# Altium schematic sheet Audio.SchDoc
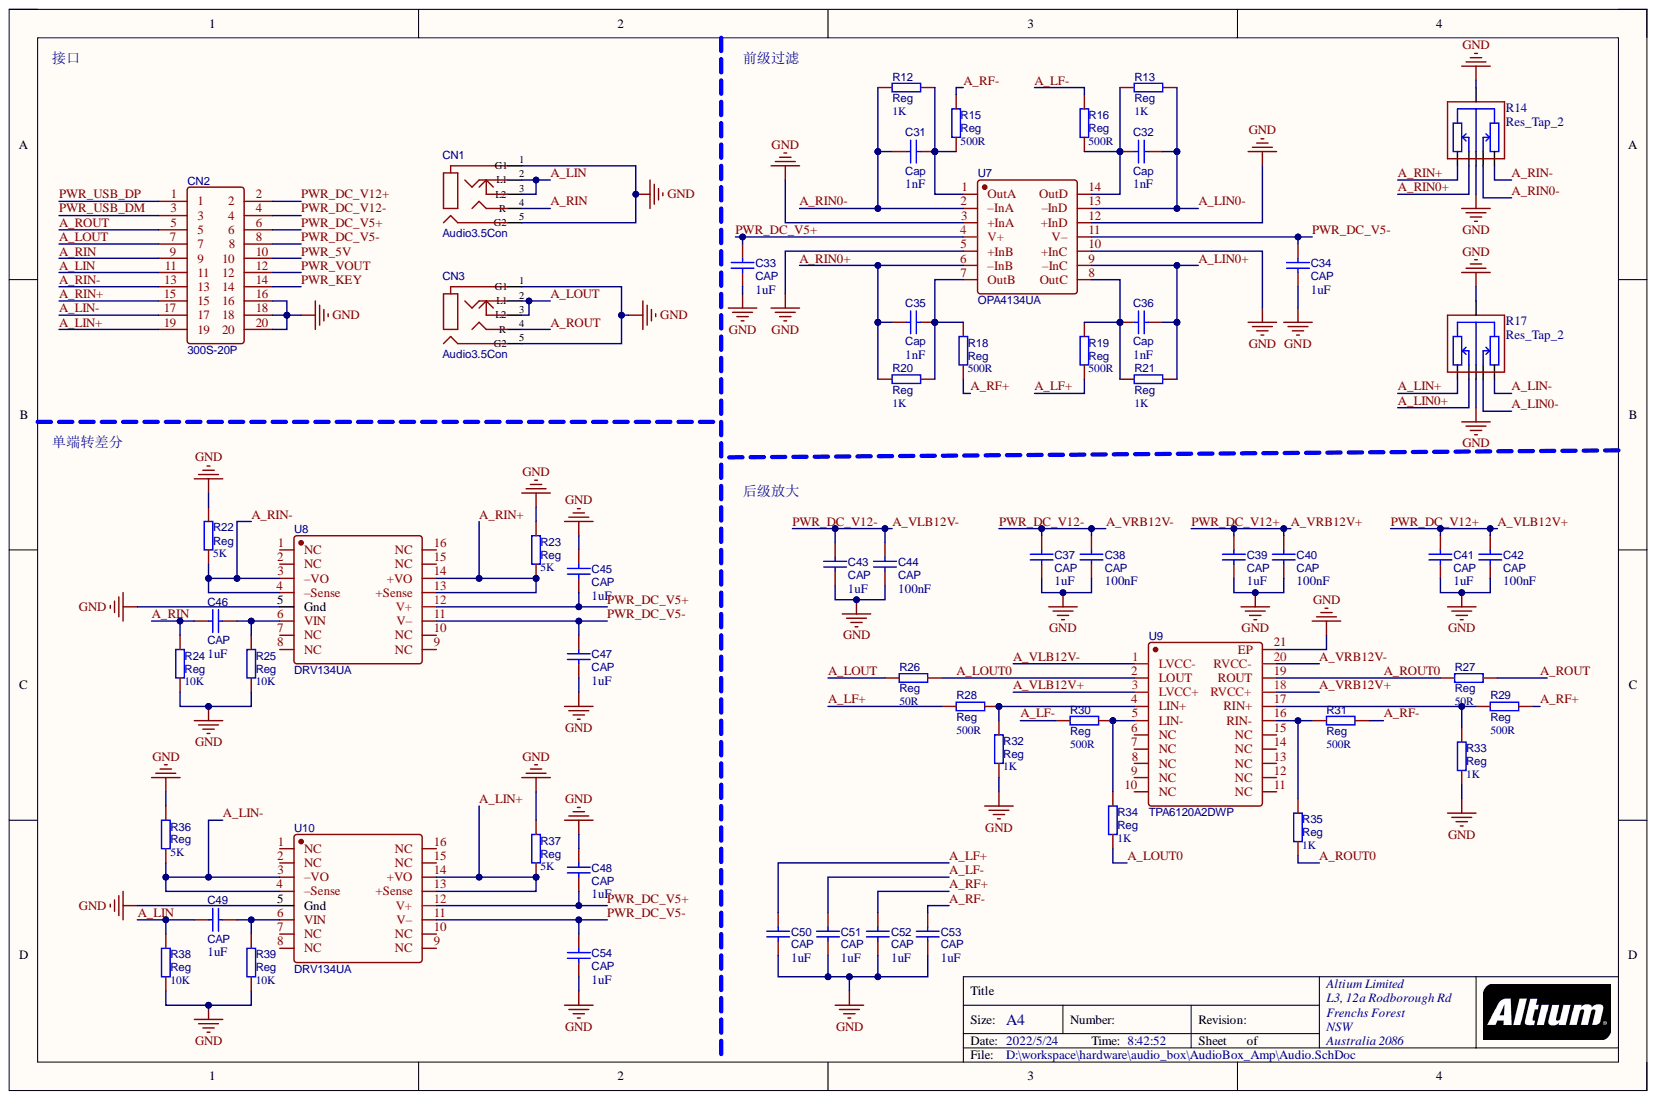
[diagram p0: Audio.SchDoc]

## Parts
C31  Cap  value 1nF  [C0603]
C32  Cap  value 1nF  [C0603]
C33  CAP  value 1uF  [C0603]
C34  CAP  value 1uF  [C0603]
C35  Cap  value 1nF  [C0603]
C36  Cap  value 1nF  [C0603]
C37  CAP  value 1uF  [C0603]
C38  CAP  value 100nF  [C0603]
C39  CAP  value 1uF  [C0603]
C40  CAP  value 100nF  [C0603]
C41  CAP  value 1uF  [C0603]
C42  CAP  value 100nF  [C0603]
C43  CAP  value 1uF  [C0603]
C44  CAP  value 100nF  [C0603]
C45  CAP  value 1uF  [C0603]
C46  CAP  value 1uF  [C0603]
C47  CAP  value 1uF  [C0603]
C48  CAP  value 1uF  [C0603]
C49  CAP  value 1uF  [C0603]
C50  CAP  value 1uF  [C0603]
C51  CAP  value 1uF  [C0603]
C52  CAP  value 1uF  [C0603]
C53  CAP  value 1uF  [C0603]
C54  CAP  value 1uF  [C0603]
CN1  Audio3.5Con  [Audio3.5_3Pin]
CN2  300S-20P  [CONN-TH_300S-20P]
CN3  Audio3.5Con  [Audio3.5_3Pin]
R12  Reg  value 1K  [R0603]
R13  Reg  value 1K  [R0603]
R14  Res_Tap_2  [RES_TAP_5Pin]
R15  Reg  value 500R  [R0603]
R16  Reg  value 500R  [R0603]
R17  Res_Tap_2  [RES_TAP_5Pin]
R18  Reg  value 500R  [R0603]
R19  Reg  value 500R  [R0603]
R20  Reg  value 1K  [R0603]
R21  Reg  value 1K  [R0603]
R22  Reg  value 5K  [R0603]
R23  Reg  value 5K  [R0603]
R24  Reg  value 10K  [R0603]
R25  Reg  value 10K  [R0603]
R26  Reg  value 50R  [R0603]
R27  Reg  value 50R  [R0603]
R28  Reg  value 500R  [R0603]
R29  Reg  value 500R  [R0603]
R30  Reg  value 500R  [R0603]
R31  Reg  value 500R  [R0603]
R32  Reg  value 1K  [R0603]
R33  Reg  value 1K  [R0603]
R34  Reg  value 1K  [R0603]
R35  Reg  value 1K  [R0603]
R36  Reg  value 5K  [R0603]
R37  Reg  value 5K  [R0603]
R38  Reg  value 10K  [R0603]
R39  Reg  value 10K  [R0603]
U7  OPA4134UA  [SOIC-14_L8.7-W3.9-P1.27-LS6.0-BL]
U8  DRV134UA  [SOIC-16_L10.3-W7.5-P1.27-LS10.3-BL]
U9  TPA6120A2DWP  [HSOP-20_L12.8-W7.5-P1.27-LS10.3-BL-EP]
U10  DRV134UA  [SOIC-16_L10.3-W7.5-P1.27-LS10.3-BL]

## Nets
GND: C33.1 C34.1 C37.1 C38.1 C39.1 C40.1 C41.1 C42.1 C43.1 C44.1 C45.2 C47.1 C48.2 C50.2 C51.2 C52.2 C53.2 C54.1 CN1.1 CN1.5(G2) CN2.16 CN2.18 CN2.20 CN3.1 CN3.5(G2) R14.1 R14.6 R17.1 R17.6 R22.2 R23.1 R24.1 R25.1 R32.1 R33.1 R36.2 R37.1 R38.1 R39.1 U7.3(+InA) U7.5(+InB) U7.10(+InC) U7.12(+InD) U8.5(Gnd) U9.21(EP) U10.5(Gnd)
A_LF+: C50.1 R19.1 R28.1
A_LF-: C51.1 R16.2 R30.1
A_LIN: C49.1 CN1.2(L1) CN1.3(L2) CN2.11 R38.2
A_LIN0+: C36.2 R17.3 R21.2 U7.9(–InC)
A_LIN0-: C32.2 R13.2 R17.2 U7.13(–InD)
A_LIN+: CN2.19 R17.4 R37.2 U10.13(+Sense) U10.14(+VO)
A_LIN-: CN2.17 R17.5 R36.1 U10.3(–VO) U10.4(–Sense)
A_LOUT: CN2.7 CN3.2(L1) CN3.3(L2) R26.1
A_LOUT0: R26.2 R34.1 U9.2(LOUT)
A_RF+: C52.1 R18.1 R29.1
A_RF-: C53.1 R15.2 R31.1
A_RIN: C46.1 CN1.4(R) CN2.9 R24.2
A_RIN0+: C35.1 R14.3 R20.1 U7.6(–InB)
A_RIN0-: C31.1 R12.1 R14.2 U7.2(–InA)
A_RIN+: CN2.15 R14.4 R23.2 U8.13(+Sense) U8.14(+VO)
A_RIN-: CN2.13 R14.5 R22.1 U8.3(–VO) U8.4(–Sense)
A_ROUT: CN2.5 CN3.4(R) R27.2
A_ROUT0: R27.1 R35.1 U9.19(ROUT)
A_VLB12V+: C39.2 C40.2 C41.2 C42.2 CN2.2 U9.3(LVCC+) U9.18(RVCC+)
A_VLB12V-: C37.2 C38.2 C43.2 C44.2 CN2.4 U9.1(LVCC-) U9.20(RVCC-)
PWR_5V: CN2.10
PWR_DC_V5+: C33.2 C45.1 C48.1 CN2.6 U7.4(V+) U8.12(V+) U10.12(V+)
PWR_DC_V5-: C34.2 C47.2 C54.2 CN2.8 U7.11(V–) U8.11(V–) U10.11(V–)
PWR_KEY: CN2.14
PWR_USB_DM: CN2.3
PWR_USB_DP: CN2.1
PWR_VOUT: CN2.12
NetC31_2: C31.2 R12.2 R15.1 U7.1(OutA)
NetC32_1: C32.1 R13.1 R16.1 U7.14(OutD)
NetC35_2: C35.2 R18.2 R20.2 U7.7(OutB)
NetC36_1: C36.1 R19.2 R21.1 U7.8(OutC)
NetC46_2: C46.2 R25.2 U8.6(VIN)
NetC49_2: C49.2 R39.2 U10.6(VIN)
NetR28_2: R28.2 R32.2 U9.4(LIN+)
NetR29_2: R29.2 R33.2 U9.17(RIN+)
NetR30_2: R30.2 R34.2 U9.5(LIN-)
NetR31_2: R31.2 R35.2 U9.16(RIN-)

Not connected: U8 1(NC) 2(NC) 7(NC) 8(NC) 9(NC) 10(NC) 15(NC) 16(NC); U9 6(NC) 7(NC) 8(NC) 9(NC) 10(NC) 11(NC) 12(NC) 13(NC) 14(NC) 15(NC); U10 1(NC) 2(NC) 7(NC) 8(NC) 9(NC) 10(NC) 15(NC) 16(NC)

## Library data of the parts
C31-C34 C37-C45 C47-C54 = CAP: BOM_Manufacturer: Huaxin S&T; BOM_Manufacturer Part: 0603B103K101CT; Contributor: LCSC; nameAlias: Capacitance; BOM_Supplier: LCSC; BOM_Supplier Part: C302008; Component Kind: Standard; Description: Cap; spicePre: C; spiceSymbolName: 0603B103K101CT; Library Name: Levent_lib.IntLib
C35 C36 = CAP: BOM_Manufacturer: Huaxin S&T; BOM_Manufacturer Part: 0603B103K101CT; Contributor: LCSC; nameAlias: Capacitance; Value: 1nF; BOM_Supplier: LCSC; BOM_Supplier Part: C302008; Component Kind: Standard; Description: Cap; spicePre: C; spiceSymbolName: 0603B103K101CT; Library Name: Levent_lib.IntLib
C46 = CAP: BOM_Manufacturer: Huaxin S&T; BOM_Manufacturer Part: 0603B103K101CT; Contributor: LCSC; nameAlias: Capacitance; Value: 1uF; BOM_Supplier: LCSC; BOM_Supplier Part: C302008; Component Kind: Standard; Description: Cap; spicePre: C; spiceSymbolName: 0603B103K101CT; Library Name: Levent_lib.IntLib
CN1 CN3 = AudioCon3: 3.5mm 3Pin 音频接口; BOM_Manufacturer: G-Switch(品赞); BOM_Manufacturer Part: PJ-393; Contributor: LCSC; BOM_JLCPCB Part Class: Extended Part; BOM_Supplier: LCSC; BOM_Supplier Part: C2837065; Component Kind: Standard; spicePre: C; spiceSymbolName: PJ-393_C2837065; Library Name: Levent_lib.IntLib
CN2 = DC-20P: DC牛角座，20P; BOM_Manufacturer: Shenzhen Cankemeng; Contributor: LCSC; BOM_JLCPCB Part Class: Extended Part; BOM_Manufacturer Part: -; BOM_Supplier: LCSC; BOM_Supplier Part: C132442; Component Kind: Standard; spicePre: C; spiceSymbolName: 300S-20P; Library Name: Levent_lib.IntLib
R12 R13 = Res: 电阻; BOM_Manufacturer: Viking Tech; BOM_Manufacturer Part: AR03BTCX1002A; Contributor: LCSC; nameAlias: Resistance (Ohms); Value: 1K; BOM_Supplier: LCSC; BOM_Supplier Part: C465721; Component Kind: Standard; spicePre: R; spiceSymbolName: AR03BTCX1002A; Library Name: Levent_lib.IntLib
R14 R17 = Res_Tap_2: 双联电位器; Component Kind: Standard; Library Name: Levent_lib.IntLib
R15 R16 R18-R26 R28-R39 = Res: 电阻; BOM_Manufacturer: Viking Tech; BOM_Manufacturer Part: AR03BTCX1002A; Contributor: LCSC; nameAlias: Resistance (Ohms); BOM_Supplier: LCSC; BOM_Supplier Part: C465721; Component Kind: Standard; spicePre: R; spiceSymbolName: AR03BTCX1002A; Library Name: Levent_lib.IntLib
R27 = Res: 电阻; BOM_Manufacturer: Viking Tech; BOM_Manufacturer Part: AR03BTCX1002A; Contributor: LCSC; nameAlias: Resistance (Ohms); Value: 50R; BOM_Supplier: LCSC; BOM_Supplier Part: C465721; Component Kind: Standard; spicePre: R; spiceSymbolName: AR03BTCX1002A; Library Name: Levent_lib.IntLib
U7 = OPA4134UA: 耳放; BOM_Manufacturer: TI(德州仪器); Contributor: LCSC; BOM_JLCPCB Part Class: Extended Part; BOM_Manufacturer Part: OPA4134UA; BOM_Supplier: LCSC; BOM_Supplier Part: C2832240; Component Kind: Standard; spicePre: U; spiceSymbolName: OPA4134UA; Library Name: Levent_lib.IntLib
U8 U10 = DRV134UA: 音频差分放大器; BOM_Manufacturer: TI(德州仪器); Contributor: LCSC; BOM_JLCPCB Part Class: Extended Part; BOM_Manufacturer Part: DRV134UA; BOM_Supplier: LCSC; BOM_Supplier Part: C1519988; Component Kind: Standard; spicePre: U; spiceSymbolName: DRV134UA; Library Name: Levent_lib.IntLib
U9 = TPA6120: 耳放; BOM_Manufacturer: TI(德州仪器); Contributor: LCSC; BOM_Manufacturer Part: TPA6120A2DWP; BOM_Supplier: LCSC; BOM_Supplier Part: C2871054; Component Kind: Standard; link: https://atta.szlcsc.comnull; spicePre: U; spiceSymbolName: TPA6120A2DWP; Library Name: Levent_lib.IntLib
